# Altium schematic sheet Driver and FETs.SchDoc
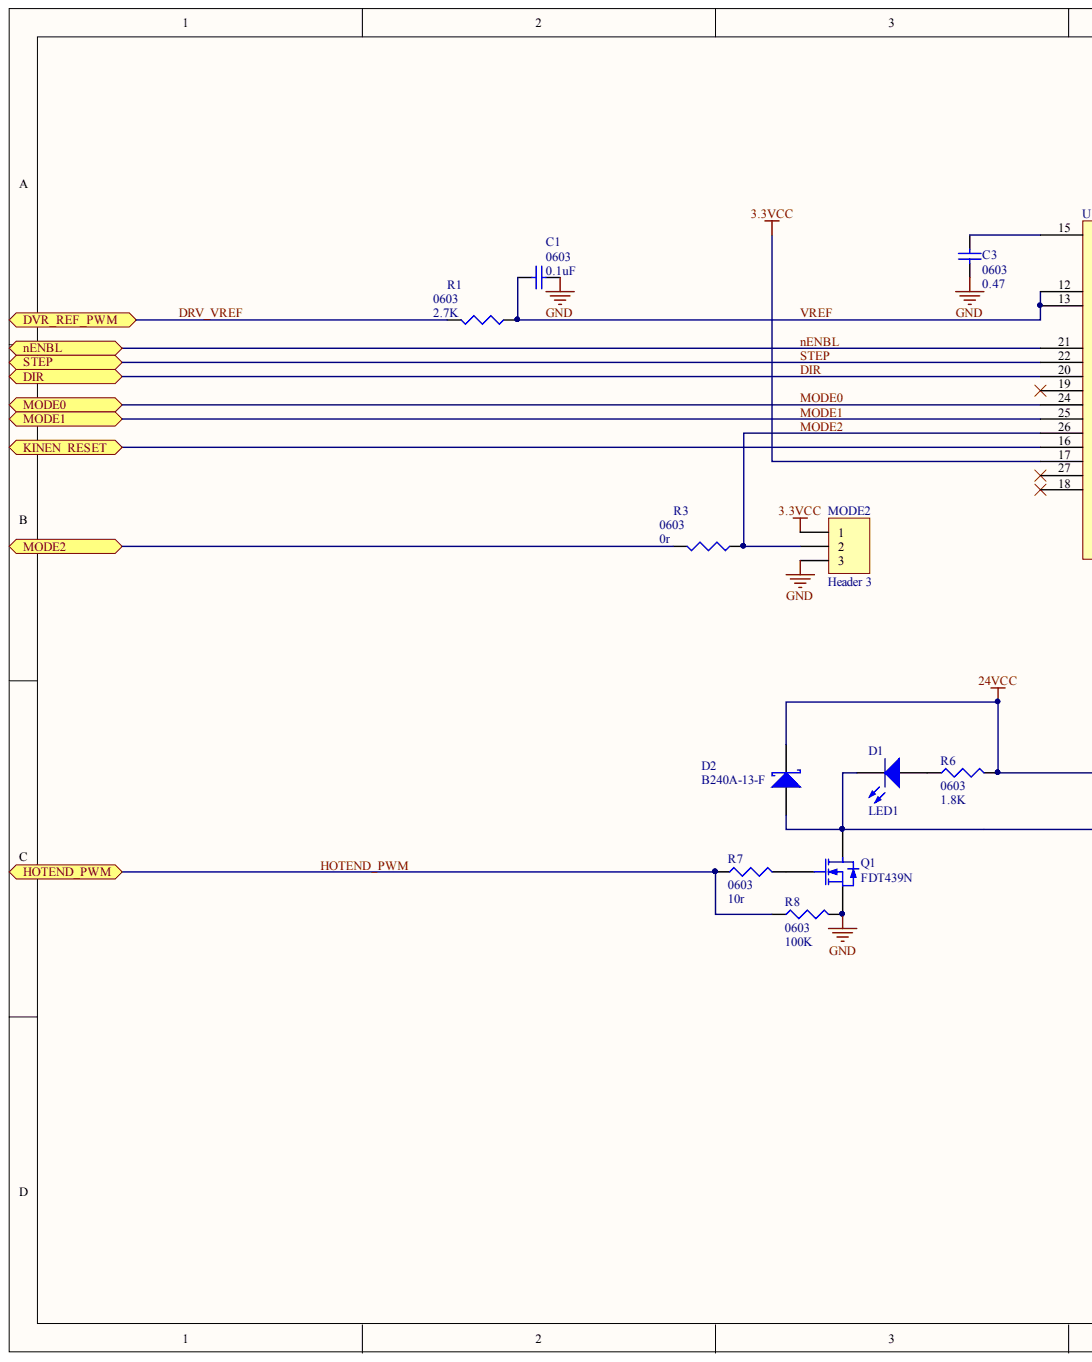
[diagram p0: Driver and FETs.SchDoc, left]
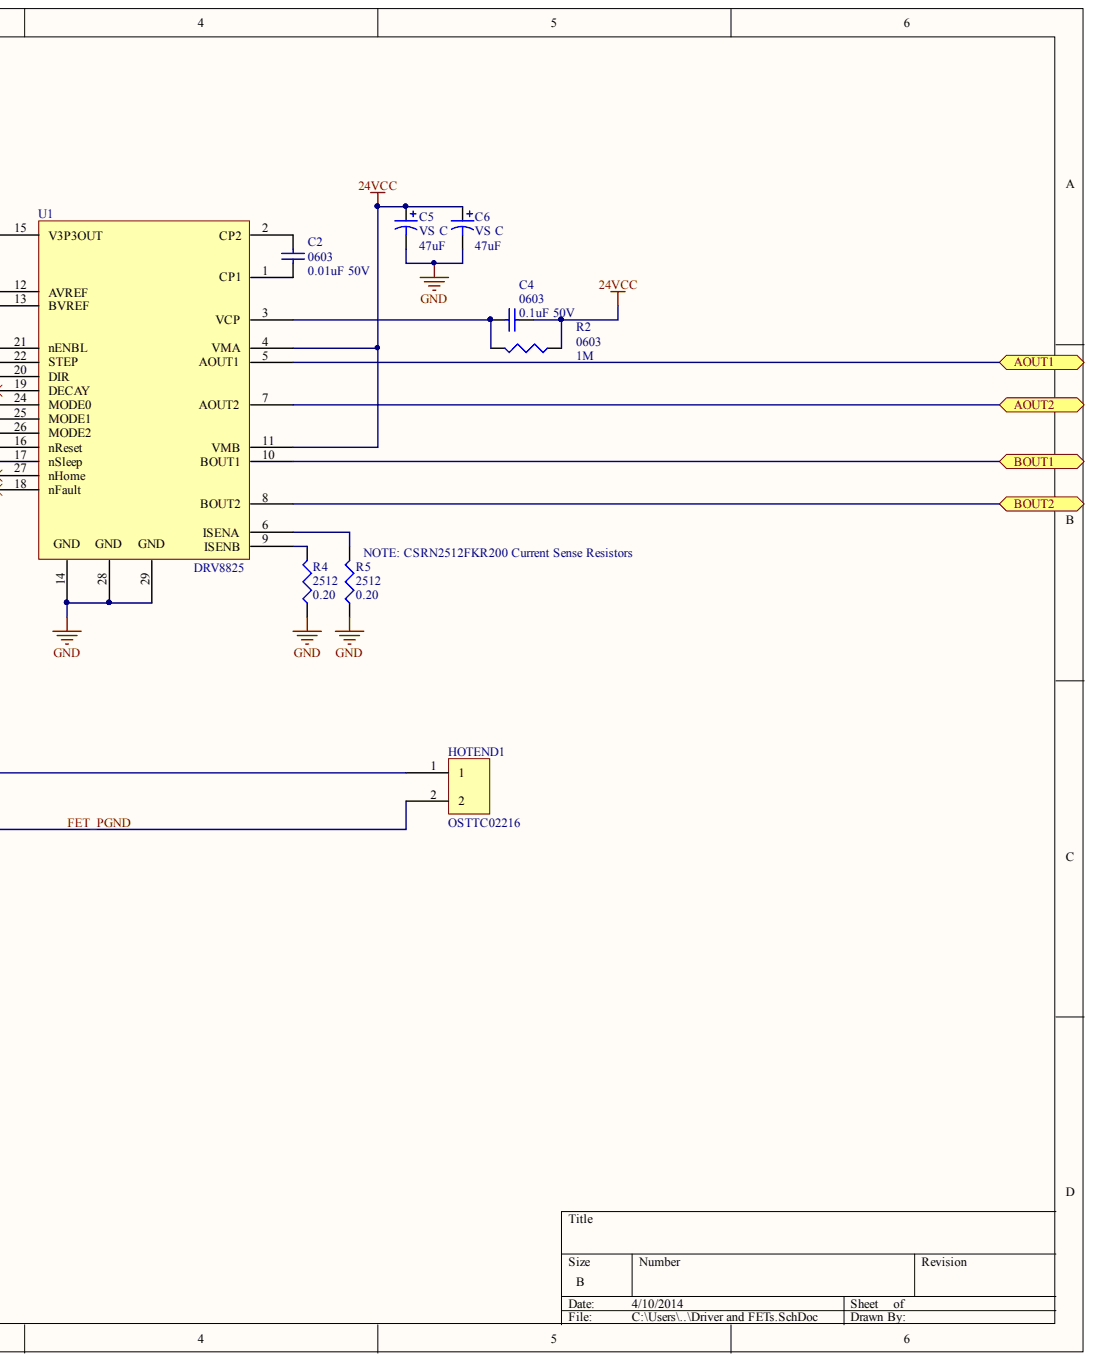
[diagram p1: Driver and FETs.SchDoc, right]

## Parts
C1  0603  value 0.1uF
C2  0603  value 0.01uF 50V
C3  0603  value 0.47
C4  0603  value 0.1uF 50V
C5  VS C  value 47uF
C6  VS C  value 47uF
D1  LED1
D2  B240A-13-F
HOTEND1  OSTTC02216
MODE2  Header 3
Q1  FDT439N
R1  0603  value 2.7K
R2  0603  value 1M
R3  0603  value 0r
R4  2512  value 0.20
R5  2512  value 0.20
R6  0603  value 1.8K
R7  0603  value 10r
R8  0603  value 100K
U1  DRV8825

## Ports
AOUT1, AOUT2, BOUT1, BOUT2, DIR, DVR_REF_PWM, HOTEND_PWM, KINEN_RESET, MODE0, MODE1, MODE2, NENBL, STEP

## Nets
2: Q1.4
3.3VCC: MODE2.1 U1.17(nSleep)
24VCC: C4.1 C5.1 C6.1 D2.2 HOTEND1.1 R2.1 R6.2 U1.4(VMA) U1.11(VMB)
GND: C1.1 C3.1 C5.2 C6.2 MODE2.3 Q1.3 R4.1 R5.1 R8.2 U1.14 U1.28 U1.29
AOUT1: U1.5(AOUT1) ; port AOUT1
AOUT2: U1.7(AOUT2) ; port AOUT2
BOUT1: U1.10(BOUT1) ; port BOUT1
BOUT2: U1.8(BOUT2) ; port BOUT2
DIR: U1.20(DIR) ; port DIR
DRV_VREF: R1.2 ; port DVR_REF_PWM
FET_PGND: D1.2 D2.1 HOTEND1.2 Q1.2
HOTEND_PWM: R7.1 R8.1 ; port HOTEND_PWM
KINEN_RESET: U1.16(nReset) ; port KINEN_RESET
MODE0: U1.24(MODE0) ; port MODE0
MODE1: U1.25(MODE1) ; port MODE1
MODE2: MODE2.2 R3.1 U1.26(MODE2)
NENBL: U1.21(nENBL) ; port NENBL
STEP: U1.22(STEP) ; port STEP
VREF: C1.2 R1.1 U1.12(AVREF) U1.13(BVREF)
NetC2_1: C2.1 U1.1(CP1)
NetC2_2: C2.2 U1.2(CP2)
NetC3_2: C3.2 U1.15(V3P3OUT)
NetC4_2: C4.2 R2.2 U1.3(VCP)
NetD1_1: D1.1 R6.1
NetQ1_1: Q1.1 R7.2
NetR3_2: R3.2 ; port MODE2
NetR4_2: R4.2 U1.9(ISENB)
NetR5_2: R5.2 U1.6(ISENA)

Not connected: U1 18(nFault) 19(DECAY) 27(nHome)

## Library data of the parts
C4 = (no symbol name): Value: 0.1uF 50V; Published: 8-Jun-2000; LatestRevisionDate: 29-May-2009; LatestRevisionNote: IPC-7351 Footprint Added.; PackageReference: C1206; PackageDescription: Chip Capacitor; Publisher: Altium Limited
C1-C3 C5 C6 D1 D2 HOTEND1 MODE2 Q1 R1-R8 U1 = (no symbol name)
  C1-C3: Published: 8-Jun-2000; LatestRevisionDate: 29-May-2009; LatestRevisionNote: IPC-7351 Footprint Added.; PackageReference: C1206; PackageDescription: Chip Capacitor
  C5 C6: Published: 8-Jun-2000; LatestRevisionDate: 17-Jul-2002; LatestRevisionNote: Re-released for DXP Platform.; PackageReference: RB7.6-15; PackageDescription: Polarized Capacitor (Radial); 2 Leads
  D1: Published: 8-Jun-2000; LatestRevisionDate: 08-Jul-2005; LatestRevisionNote: Mech Layer 1 removed.; PackageReference: LED-1; PackageDescription: LED; 2 Leads
  D2: Published: 8-Jun-2000; LatestRevisionDate: 17-Jul-2002; LatestRevisionNote: Re-released for DXP Platform.; PackageReference: SMB; PackageDescription: DO-214-AA/SMB; 2 C-Bend Leads; Body 5.3 x 3.6 mm, inc. leads (LxW); Code_JEDEC: DO-214-AA
  MODE2: LatestRevisionDate: 17-Jul-2002; LatestRevisionNote: Re-released for DXP Platform.
  Q1: Published: 8-Jun-2000; LatestRevisionDate: 17-Jul-2002; LatestRevisionNote: Re-released for DXP Platform.; PackageVersion: 1995/1996; PackageReference: E3; PackageDescription: TO, Flat Index; 3 In-Line, Axial Leads; Body Dia. 4.6mm; Lead Dia. 0.5 mm (max)
  R1-R8: Published: 8-Jun-2000; LatestRevisionDate: 29-May-2009; LatestRevisionNote: IPC-7351 Footprint Added.; PackageReference: J1-0603; PackageDescription: Chip Resistor
  C1-C3 C5 C6 D1 D2 MODE2 Q1 R1-R8: Publisher: Altium Limited
